# Altium schematic sheet AUDIO&ETHNET.SchDoc(AUDIO&ETHNET)
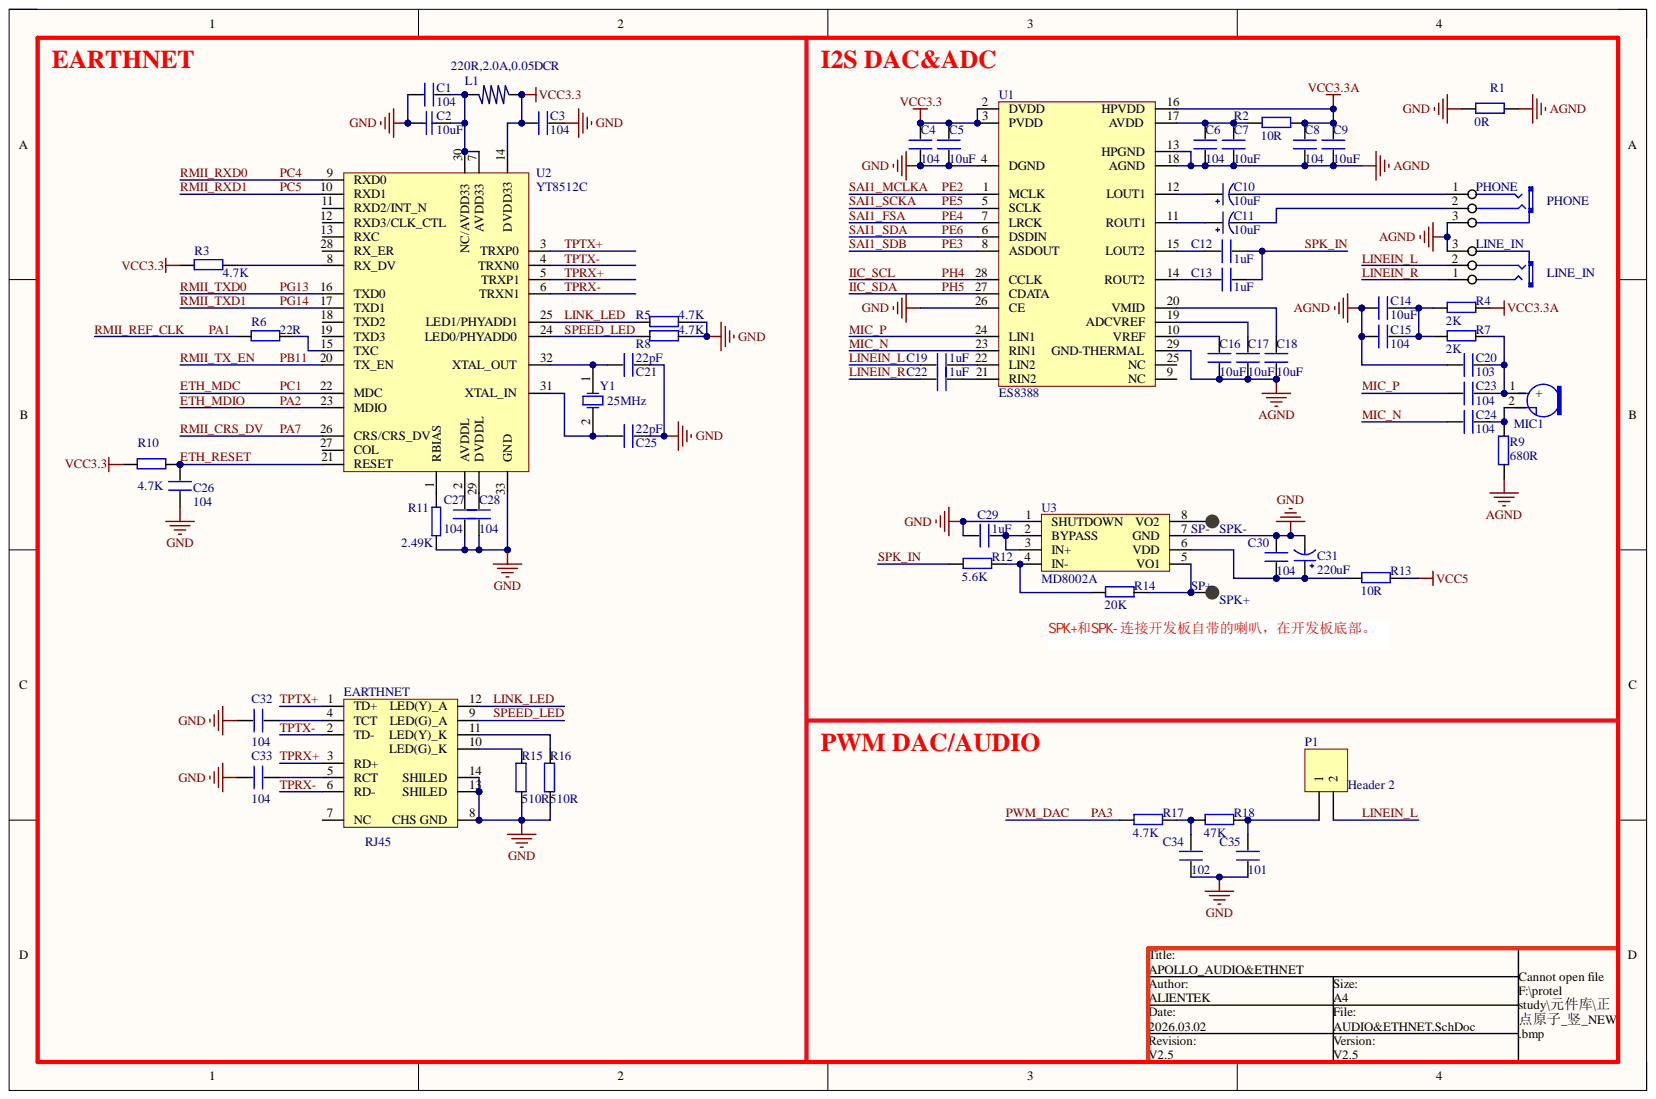
[diagram p0: AUDIO&ETHNET.SchDoc]

## Parts
C1  104  [0402]
C2  10uF  [0603P]
C3  104  [0402]
C4  104  [0402]
C5  10uF  [0603P]
C6  104  [0402]
C7  10uF  [0603P]
C8  104  [0402]
C9  10uF  [0603P]
C10  10uF  [0603P]
C11  10uF  [0603P]
C12  1uF  [0402]
C13  1uF  [0402]
C14  10uF  [0603P]
C15  104  [0402]
C16  10uF  [0603P]
C17  10uF  [0603P]
C18  10uF  [0603P]
C19  1uF  [0402]
C20  103  [0402]
C21  22pF  [0402]
C22  1uF  [0402]
C23  104  [0402]
C24  104  [0402]
C25  22pF  [0402]
C26  104  [0402]
C27  104  [0402]
C28  104  [0402]
C29  1uF  [0402]
C30  104  [0402]
C31  220uF  [CC6.56.5]
C32  104  [0402]  (value not drawn)
C33  104  [0402]
C34  102  [0402]
C35  101  [0402]
EARTHNET  RJ45  [HR911105A]
L1  220R,2.0A,0.05DCR  [0603P]
LINE_IN  LINE_IN  [PHONE_ST]
MIC1  MIC-6022  [MIC-6022]  (value not drawn)
P1  Header 2  [HDR1X2]
PHONE  PHONE  [PHONE_ST]
R1  0R  [0402]
R2  10R  [0402]
R3  4.7K  [0402]
R4  2K  [0402]
R5  4.7K  [0402]
R6  22R  [0402]
R7  2K  [0402]
R8  4.7K  [0402]
R9  680R  [0402]
R10  4.7K  [0402]
R11  2.49K  [0402]
R12  5.6K  [0402]
R13  10R  [0402]
R14  20K  [0402]
R15  510R  [0402]
R16  510R  [0402]
R17  4.7K  [0402]
R18  47K  [0402]
SP+  SPK+  [TP-1.5]
SP-  SPK-  [TP-1.5]
U1  ES8388  [qfn28]
U2  YT8512C  [YT8512C]
U3  MD8002A  [SOP-8]
Y1  25MHz  [XTAL_US]

## Nets
AGND: C6.1 C7.1 C8.1 C9.1 C14.2 C15.1 C16.1 C17.1 C18.1 C20.2 LINE_IN.3 PHONE.3 R1.1 R9.2 U1.13(HPGND) U1.18(AGND) U1.29(GND-THERMAL)
GND: C1.1 C2.2 C3.1 C4.1 C5.1 C21.1 C25.1 C26.1 C27.1 C28.1 C29.1 C30.2 C31.2 C32.1 C33.1 C34.1 C35.1 EARTHNET.8(CHS_GND) EARTHNET.13(SHILED) EARTHNET.14(SHILED) R1.2 R5.1 R8.1 R11.2 R15.2 R16.2 U1.4(DGND) U1.26(CE) U2.33(GND) U3.1(SHUTDOWN) U3.7(GND)
VCC3.3: C3.2 C4.2 C5.2 L1.1 R3.2 R10.2 U1.2(DVDD) U1.3(PVDD) U2.14(DVDD33)
VCC3.3A: C8.2 C9.2 R2.1 R4.1 U1.16(HPVDD)
VCC5: R13.1
ETH_MDC: U2.22(MDC)
ETH_MDIO: U2.23(MDIO)
ETH_RESET: C26.2 R10.1 U2.21(RESET)
IIC_SCL: U1.28(CCLK)
IIC_SDA: U1.27(CDATA)
LINEIN_L: C19.1 LINE_IN.2 P1.2
LINEIN_R: C22.1 LINE_IN.1
LINK_LED: EARTHNET.12(LED(Y)_A) R5.2 U2.25(LED1/PHYADD1)
MIC_N: C24.2 U1.23(RIN1)
MIC_P: C23.2 U1.24(LIN1)
PA1: R6.2
PA3: R17.2
PA7: U2.26(CRS/CRS_DV)
PB11: U2.20(TX_EN)
PC4: U2.9(RXD0)
PC5: U2.10(RXD1)
PE2: U1.1(MCLK)
PE3: U1.8(ASDOUT)
PE4: U1.7(LRCK)
PE5: U1.5(SCLK)
PE6: U1.6(DSDIN)
PG13: U2.16(TXD0)
PG14: U2.17(TXD1)
SPEED_LED: EARTHNET.9(LED(G)_A) R8.2 U2.24(LED0/PHYADD0)
SPK_IN: C12.2 C13.2 R12.2
TPRX+: EARTHNET.3(RD+) U2.5(TRXP1)
TPRX-: EARTHNET.6(RD-) U2.6(TRXN1)
TPTX+: EARTHNET.1(TD+) U2.3(TRXP0)
TPTX-: EARTHNET.2(TD-) U2.4(TRXN0)
NetC1_2: C1.2 C2.1 L1.2 U2.7(AVDD33) U2.30(NC/AVDD33)
NetC6_2: C6.2 C7.2 R2.2 U1.17(AVDD)
NetC10_1: C10.1 U1.12(LOUT1)
NetC10_2: C10.2 PHONE.1
NetC11_1: C11.1 U1.11(ROUT1)
NetC11_2: C11.2 PHONE.2
NetC12_1: C12.1 U1.15(LOUT2)
NetC13_1: C13.1 U1.14(ROUT2)
NetC14_1: C14.1 C15.2 R4.2 R7.2
NetC16_2: C16.2 U1.10(VREF)
NetC17_2: C17.2 U1.19(ADCVREF)
NetC18_2: C18.2 U1.20(VMID)
NetC19_2: C19.2 U1.22(LIN2)
NetC20_1: C20.1 C23.1 MIC1.1 R7.1
NetC21_2: C21.2 U2.32(XTAL_OUT) Y1.1
NetC22_2: C22.2 U1.21(RIN2)
NetC24_1: C24.1 MIC1.2 R9.1
NetC25_2: C25.2 U2.31(XTAL_IN) Y1.2
NetC27_2: C27.2 U2.2(AVDDL)
NetC28_2: C28.2 U2.29(DVDDL)
NetC29_2: C29.2 U3.2(BYPASS) U3.3(IN+)
NetC30_1: C30.1 C31.1 R13.2 U3.6(VDD)
NetC32_2: C32.2 EARTHNET.4(TCT)
NetC33_2: C33.2 EARTHNET.5(RCT)
NetC34_2: C34.2 R17.1 R18.2
NetC35_2: C35.2 P1.1 R18.1
NetEARTHNET_10: EARTHNET.10(LED(G)_K) R15.1
NetEARTHNET_11: EARTHNET.11(LED(Y)_K) R16.1
NetR3_1: R3.1 U2.8(RX_DV)
NetR6_1: R6.1 U2.15(TXC)
NetR11_1: R11.1 U2.1(RBIAS)
NetR12_1: R12.1 R14.2 U3.4(IN-)
NetR14_1: R14.1 SP+.1 U3.5(VO1)
NetSP-_1: SP-.1 U3.8(VO2)

Not connected: EARTHNET 7(NC); U1 9(NC) 25(NC); U2 11(RXD2/INT_N) 12(RXD3/CLK_CTL) 13(RXC) 18(TXD2) 19(TXD3) 27(COL) 28(RX_ER)

## Library data of the parts
C1 C3-C9 C12-C30 C32-C35 = C: Component Kind: Standard
  C1 C3: Library Name: STM32F767核心板封装库.IntLib
  C4-C9 C12-C20 C22-C24 C29: Library Name: ALIENTEK_COMPONENT.IntLib
  C21 C25-C28 C30 C32-C35: Library Name: MYCOMLIB.IntLib
C2 = C-0603-SMD: Component Kind: Standard; Library Name: Mic_Phone_V5.1.SCHLIB
C10 C11 C31 = CAP: Component Kind: Standard
  C10 C11: Library Name: ALIENTEK_COMPONENT.IntLib
  C31: Library Name: MYCOMLIB.IntLib
EARTHNET = HR911105: Component Kind: Standard; Library Name: MYCOMLIB.IntLib
L1 = L: Component Kind: Standard; Library Name: ALIENTEK_COMPONENT.IntLib
LINE_IN PHONE = PHONE_M: Component Kind: Standard; Library Name: ALIENTEK_COMPONENT.IntLib
MIC1 = MIC-6022: MIC; Component Kind: Standard; Library Name: Alientek_Integrated_Library.IntLib
P1 = Header 2: Header, 2-Pin; Component Kind: Standard; LatestRevisionDate: 17-Jul-2002; LatestRevisionNote: Re-released for DXP Platform.; Library Name: Miscellaneous Connectors.IntLib; Publisher: Altium Limited
R1-R10 R12-R18 = R: Component Kind: Standard
  R1 R2 R4 R7 R9 R12-R18: Library Name: MYCOMLIB.IntLib
  R3 R5 R6 R8 R10: Library Name: 阿波罗STM32F4&F7底板封装库.IntLib
R11 = R: 贴片电阻; Component Kind: Standard; Library Name: TTL2ETH.SCHLIB
SP+ SP- = TEST-POINT: 测试点; Component Kind: Standard; Library Name: ALIENTEK_COMPONENT.IntLib
U1 = ES8388: 24bit ADC&DAC 96Khz; Component Kind: Standard; Library Name: ALIENTEK_COMPONENT.IntLib
U2 = YT8512C: 10M/100M 网络PHY RMII/MII; Component Kind: Standard; Library Name: ALIENTEK_COMPONENT.IntLib
U3 = MD8002A: 5V, 3W, 功放IC; Component Kind: Standard; Library Name: ALIENTEK_COMPONENT.IntLib
Y1 = XTAL: Crystal Oscillator; Component Kind: Standard; LatestRevisionDate: 17-Jul-2002; LatestRevisionNote: Re-released for DXP Platform.; Library Name: Miscellaneous Devices.IntLib; Published: 8-Jun-2000; Simulation: XTAL; Publisher: Altium Limited
